# Altium schematic sheet MCU.SchDoc(MCU)
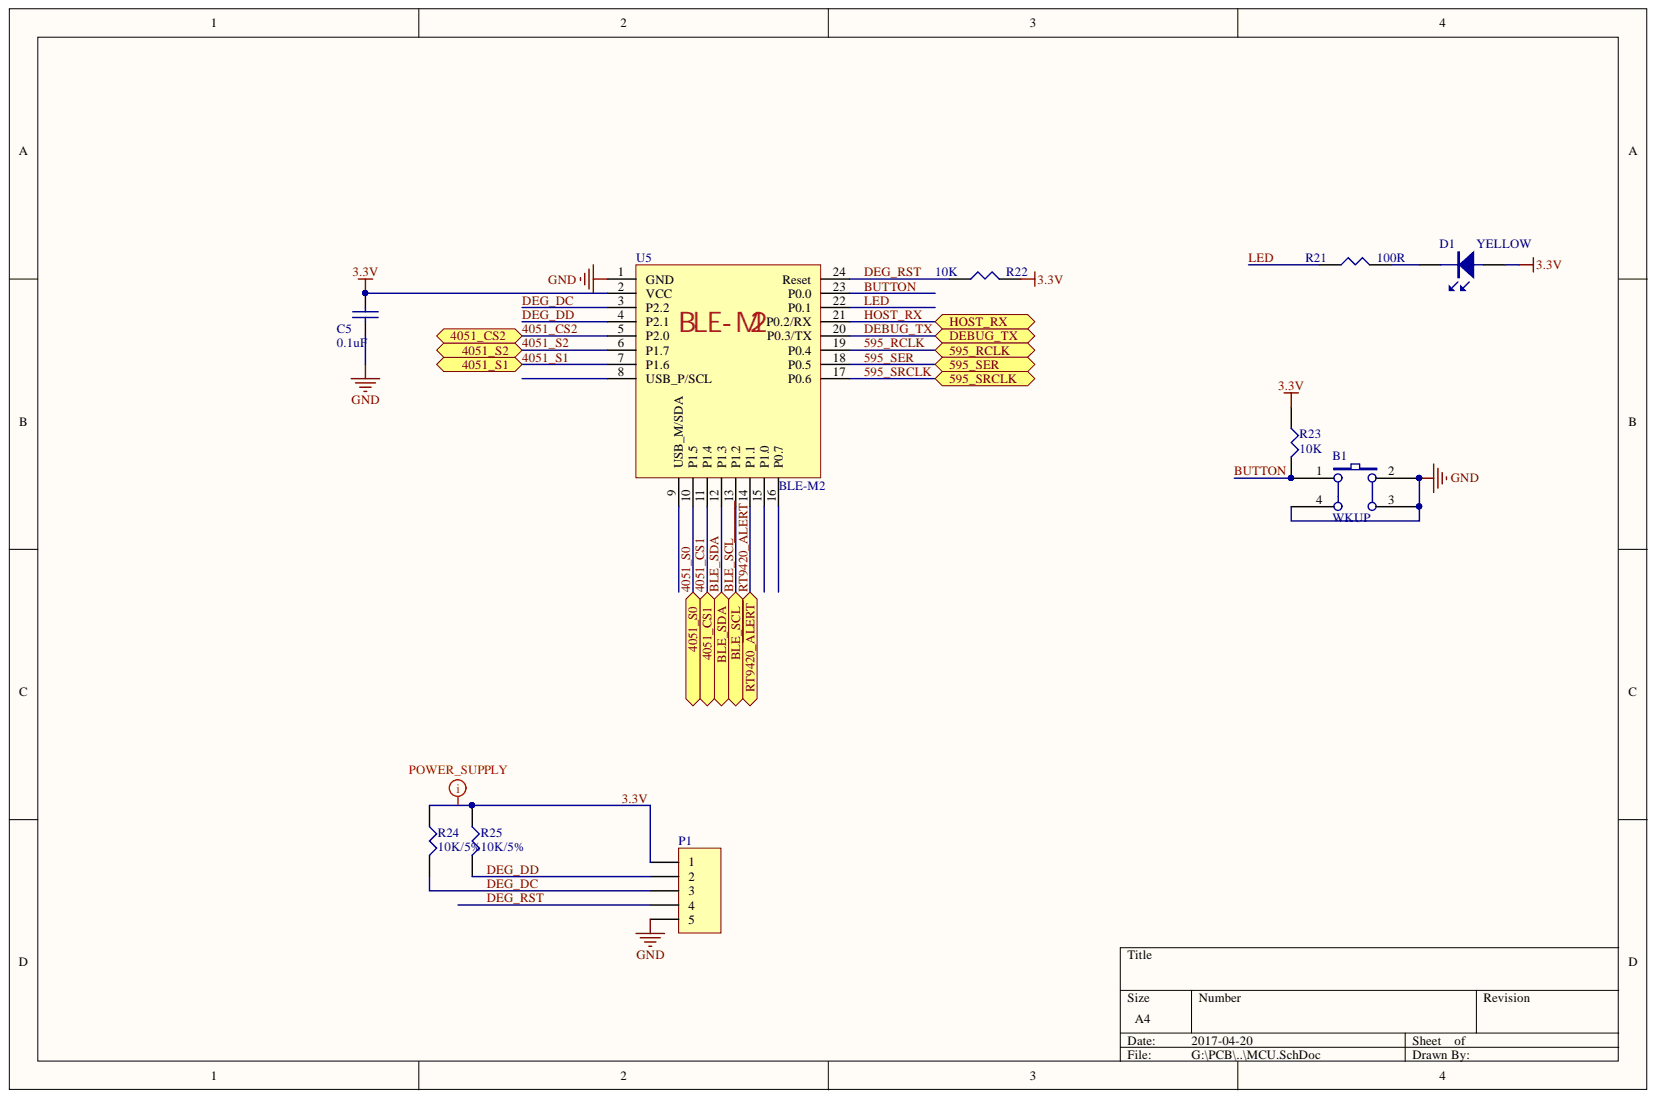
[diagram p0: MCU.SchDoc]

## Parts
B1  WKUP  [SW-EVQQ2]
C5  0.1uF  [0402C-S]
D1  YELLOW  [0603D]
P1  Header 5  [2MMx5]  (value not drawn)
R21  100R  [0402R-S]
R22  10K  [0402R-S]
R23  10K  [0402R-S]
R24  10K/5%  [0402R-S]
R25  10K/5%  [0402R-S]
U5  BLE-M2  [BLE-M2]

## Ports
595_RCLK, 595_SER, 595_SRCLK, 4051_CS1, 4051_CS2, 4051_S0, 4051_S1, 4051_S2, BLE_SCL, BLE_SDA, DEBUG_TX, HOST_RX, RT9420_~{ALERT}

## Nets
GND: B1.2 B1.3 B1.4 C5.2 P1.5 U5.1(GND)
3.3V: C5.1 D1.2 P1.1 R22.2 R23.1 R24.1 R25.1 U5.2(VCC)
595_RCLK: U5.19(P0.4) ; port 595_RCLK
595_SER: U5.18(P0.5) ; port 595_SER
595_SRCLK: U5.17(P0.6) ; port 595_SRCLK
4051_CS1: U5.11(P1.4) ; port 4051_CS1
4051_CS2: U5.5(P2.0) ; port 4051_CS2
4051_S0: U5.10(P1.5) ; port 4051_S0
4051_S1: U5.7(P1.6) ; port 4051_S1
4051_S2: U5.6(P1.7) ; port 4051_S2
BLE_SCL: U5.13(P1.2) ; port BLE_SCL
BLE_SDA: U5.12(P1.3) ; port BLE_SDA
BUTTON: B1.1 R23.2 U5.23(P0.0)
DEBUG_TX: U5.20(P0.3/TX) ; port DEBUG_TX
DEG_DC: P1.3 R24.2 U5.3(P2.2)
DEG_DD: P1.2 R25.2 U5.4(P2.1)
DEG_RST: P1.4 R22.1 U5.24(Reset)
HOST_RX: U5.21(P0.2/RX) ; port HOST_RX
LED: R21.1 U5.22(P0.1)
RT9420_~{ALERT}: U5.14(P1.1) ; port RT9420_~{ALERT}
NetD1_1: D1.1 R21.2

Not connected: U5 8(USB_P/SCL) 9(USB_M/SDA) 15(P1.0) 16(P0.7)

## Library data of the parts
B1 = SW PUSHBUTTON: SE PUSHBUTTON; Component Kind: Standard; Library Name: AirNut Library.SchLib
C5 = CAP: 0402; Component Kind: Standard; Library Name: MoJi LIB.SchLib
D1 = LED: Component Kind: Standard; Library Name: AirNut Library.SchLib
P1 = Header 5: Header, 5-Pin; Component Kind: Standard; LatestRevisionDate: 17-Jul-2002; LatestRevisionNote: Re-released for DXP Platform.; Library Name: S_2.SCHLIB; Signal Integrity: Connector; Publisher: Altium Limited
R21 R22 R24 R25 = RES: Component Kind: Standard
  R24 R25: Library Name: Schlib1.SchLib
  R21 R22: Library Name: AirNut Library.SchLib
R23 = RES1: Component Kind: Standard
U5 = BLE-M2: Component Kind: Standard; Library Name: AirNut Library.SchLib
